# Altium schematic sheet SCM20260D-Power.SchDoc(SCM20260D-Power)
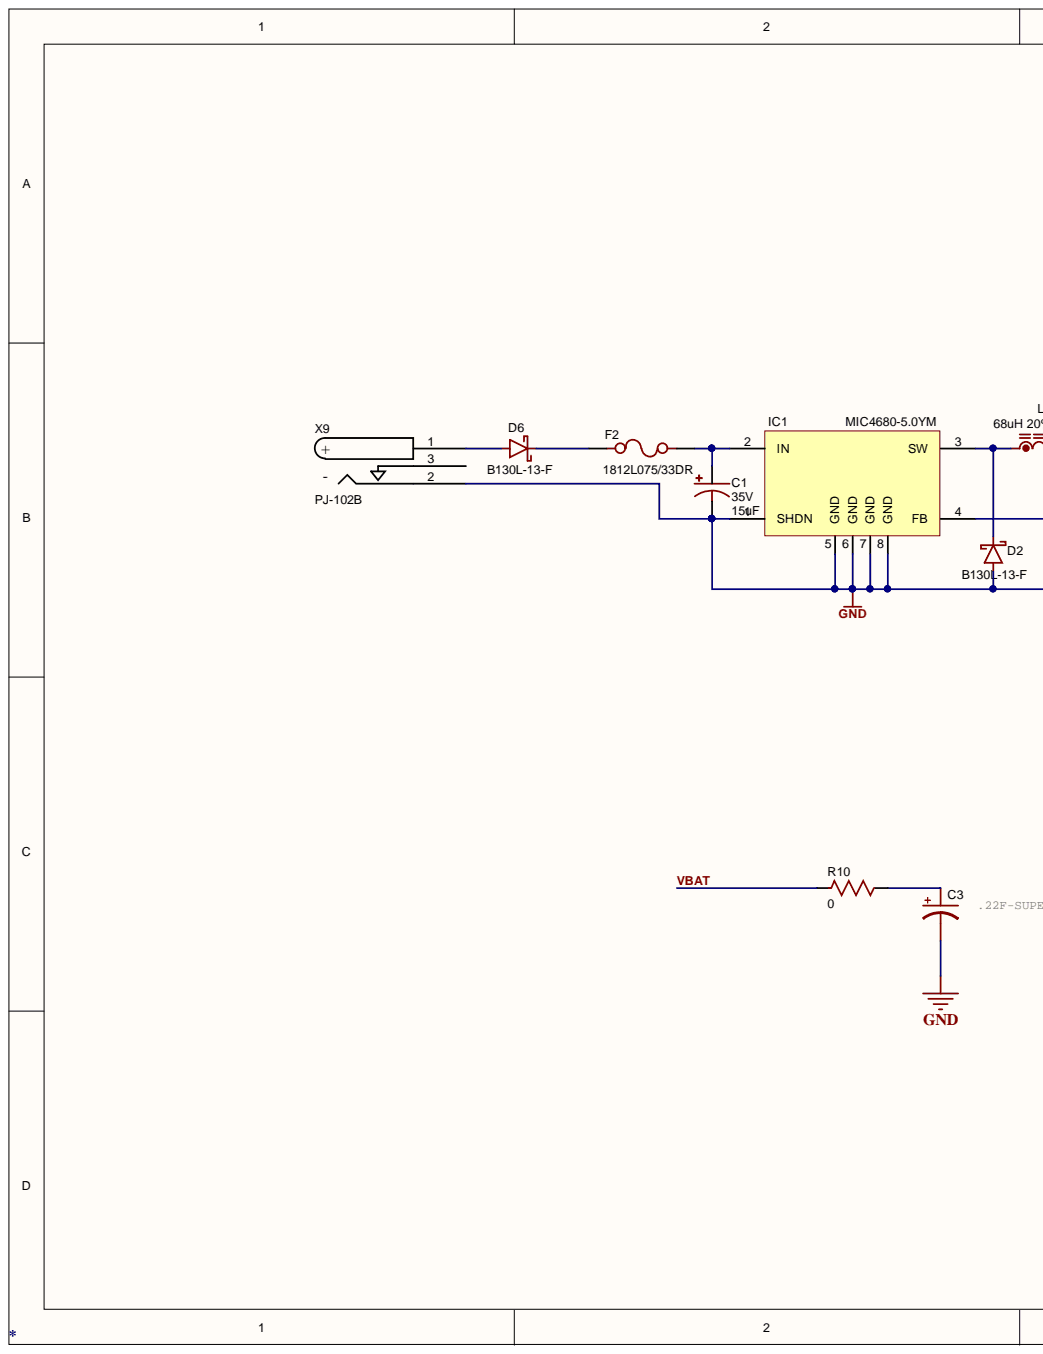
[diagram p0: SCM20260D-Power.SchDoc, left]
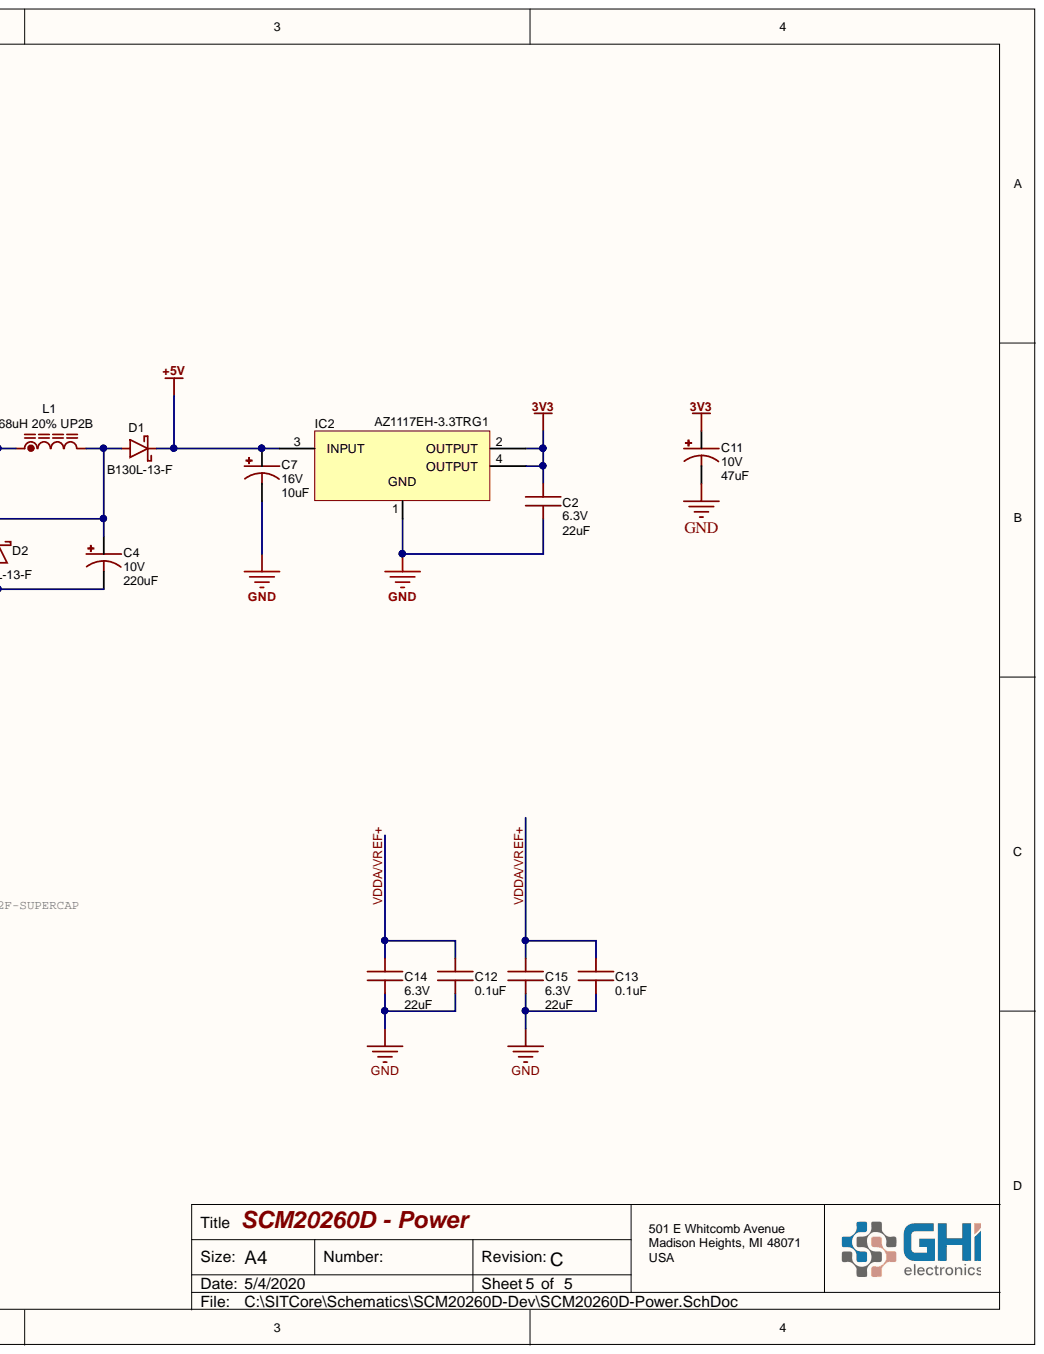
[diagram p1: SCM20260D-Power.SchDoc, right]

## Parts
C1  value 15uF  Voltage: 35V  [CASE-D (7343 METRIC)]  (hidden comment: CASE-D 7343 Tant 15uF)
C2  value 22uF  Voltage: 6.3V  [C0805]  (hidden comment: 0805 Cap 22uF)
C3  .22F-SUPERCAP  [DSK-RADIAL-6.8MM]
C4  value 220uF  Voltage: 10V  [CASE-D (7343 METRIC)]  (hidden comment: CASE-D 7343 Tant 220uF)
C7  value 10uF  Voltage: 16V  [CASE-B (3528 METRIC)]  (hidden comment: CASE-B  3528 Tant 10uF)
C11  value 47uF  Voltage: 10V  [CASE-B (3528 METRIC)]  (hidden comment: CASE-B  Tant 47uF)
C12  value 0.1uF  [C0603 (1608 Metric)]  (hidden comment: 0603 Cap 0.1uF)
C13  value 0.1uF  [C0603 (1608 Metric)]  (hidden comment: 0603 Cap 0.1uF)
C14  value 22uF  Voltage: 6.3V  [C0805]  (hidden comment: 0805 Cap 22uF)
C15  value 22uF  Voltage: 6.3V  [C0805]  (hidden comment: 0805 Cap 22uF)
D1  B130L-13-F  [SMA]
D2  B130L-13-F  [SMA]
D6  B130L-13-F  [SMA]
F2  value 1812L075/33DR  [1812 Fuse]
IC1  MIC4680-5.0YM  [8-Pin SOIC]
IC2  AZ1117EH-3.3TRG1  [SOT223]
L1  68uH 20% UP2B  Tolerance: 20%  Package: UP2B  Inductance: 68uH  [UP2BXXX]
R10  value 0  [R0603 (1608 Metric)]  (hidden comment: 0603 Res 0)
X9  PJ-102B  [PJ-102B Power Connector]

## Nets
3V3: C2.2 C11.+ IC2.2(OUTPUT) IC2.4(OUTPUT)
+5V: C7.+ D1.K IC2.3(INPUT)
GND: C1.- C2.1 C3.- C4.- C7.- C11.- C12.1 C13.1 C14.1 C15.1 D2.A IC1.1(SHDN) IC1.5(GND) IC1.6(GND) IC1.7(GND) IC1.8(GND) IC2.1 X9.2
VBAT: R10.1
VDDA/VREF+: C12.2 C13.2 C14.2 C15.2
NetC1_+: C1.+ F2.2 IC1.2(IN)
NetC3_+: C3.+ R10.2
NetC4_+: C4.+ D1.A IC1.4(FB) L1.2
NetD2_K: D2.K IC1.3(SW) L1.1
NetD6_A: D6.A X9.1
NetD6_K: D6.K F2.1

Not connected: X9 3

## Library data of the parts
C1 = CASE-D 7343 Tant 15uF: 15uF ±10% 35V 700 mΩ @ 100kHz -55℃ ~ +125℃ CASE-D_7343 Tantalum Capacitors RoHS; Mfr.Part #: 293D156X9035D2TE3; Tolerance: ±10%; Package: CASE-D_7343; LCSC Part #: C162325; Component Kind: Standard; Category: Tantalum; Capacitance: 15uF; Library Name: GHI-Passives.SchLib
C2 C14 C15 = 0805 Cap 22uF: 22uF ±20% 6.3V X5R 0805 Multilayer Ceramic Capacitors MLCC - SMD/SMT RoHS; Mfr.Part #: CL21A226MQCLRNC; Tolerance: ±20%; Package: 0805; LCSC Part #: C307530; Component Kind: Standard; Category: Ceramic; Capacitance: 22uF; Library Name: GHI-Passives.SchLib
C3 = .22F-SUPERCAP: ELNA DSK-621 - 220mF Electric Double Layer Capacitor (EDLC/SuperCapacitor)    A 220 mF SuperCapacitor. Used for backup power on devices with low standby current; Value: DSK-3R3H224U-HL; SymbolName_1: CAP_POL; GateName_1: G$1; PartNo: DSK-3R3H224U-HL; LibraryName: SparkFun-Capacitors; DeviceSetName: .22F-SUPERCAP; Component Kind: Standard; Library Name: GHI.SchLib
C4 = CASE-D 7343 Tant 220uF: 220uF ±10% 10V 500 mΩ @ 100kHz -55℃ ~ +125℃ CASE-D_7343 Tantalum Capacitors RoHS; Mfr.Part #: T491D227K010AT; Manufacturer: KEMET; Tolerance: ±10%; Package: CASE-D_7343; LCSC Part #: C116577; Component Kind: Standard; Category: Tantalum; Capacitance: 220uF; Library Name: GHI-Passives.SchLib
C7 = CASE-B Tant 10uF: 10uF ±10% 16V 800 mΩ @ 100kHz -55℃ ~ +125℃ CASE-B_3528 Tantalum Capacitors RoHS; Mfr.Part #: TPSB106K016R0800; Tolerance: ±10%; Package: CASE-B_3528; LCSC Part #: C273667; Digikey: 478-2398-1-ND; Component Kind: Standard; Category: Tantalum; Capacitance: 10uF; Library Name: GHI-Passives.SchLib
C11 = CASE-B  Tant 47uF: 47uF ±10% 10V 1 Ω @ 100kHz -55℃ ~ +125℃ CASE-B_3528 Tantalum Capacitors RoHS; Mfr.Part #: TAJB476K010RNJ; Tolerance: ±10%; Package: CASE-B 3528; LCSC Part #: C22036; Component Kind: Standard; Category: Tantalum; Capacitance: 47uF; Library Name: GHI-Passives.SchLib
C12 C13 = 0603 Cap 0.1uF: 0.1uF ±5% 50V X7R 0603 Multilayer Ceramic Capacitors MLCC - SMD/SMT RoHS; Mfr.Part #: CL10B104JB8NNNC; Voltage: 50V; Tolerance: ±5%; Package: 0603; LCSC Part #: C24452; Digikey: 1276-1033-1-ND; Component Kind: Standard; Category: Ceramic; Capacitance: 0.1uF; Library Name: GHI-Passives.SchLib
D1 D2 D6 = B130L-13-F 1A Schottky SMA: 30V 1A 410mV @ 1A SMA(DO-214AC) Schottky Barrier Diodes (SBD) RoHS; Manufacturer: Diodes Incorporated; GHI Part Number: EPC-DB130L-13; Value: B130L-13-F; Package: SMA(DO-214AC); Mfr.Part #: B130L-13-F; Library Name: GHI.SchLib; LCSC Part #: C134425; Component Type: Diode; Component Kind: Standard
F2 = FUSE 33V 750MA 1812: Polymeric PTC Resettable Fuse 33V 750mA Ih Surface Mount 1812 (4532 Metric), Concave; Manufacturer: Littelfuse Inc.; Voltage - Max: PTC RESET FUSE 33V 750MA 1812; Value: 1812L075/33DR; Current - Trip (It): 1.5A; Package: 1812; Mfr.Part #: 1812L075/33DR; LCSC Part #: C151170; Component Kind: Standard; : FUSE 33V 750MA 1812; Library Name: GHI-Passives.SchLib
IC1 = MIC4680-5.0YM: Micrel 1A 200kHz SuperSwitcher Buck Regulator; Value: MIC4680-5.0YM; PartNo: MIC4680-5.0YM; Component Kind: Standard; Library Name: GHI.SchLib
IC2 = AZ1117EH-3.3TRG1: Fixed 13V 3.3V 1A SOT-223 Low Dropout Regulators(LDO) RoHS; Manufacturer: Diodes Incorporated; Value: AZ1117EH-3.3TRG1; Package: SOT-223; Mfr.Part #: AZ1117EH-3.3TRG1; LCSC Part #: C108494; Component Kind: Standard; Library Name: GHI-Power.SchLib
L1 = 68uH 20%: Inductor 6.8uH 20% UP2B; GHI Part Number: EPC-LUP25-680; Value: UP2B-680-R; PartNo: UP2B-680-R; Library Name: GHI Basic Components.SchLib; Component Type: Inductor; Component Kind: Standard
R10 = 0603 Res 0: ±5% 1/10W 0603 Chip Resistor - Surface Mount RoHS; Mfr.Part #: RC0603JR-070RL; Manufacturer: YAGEO; Voltage Rating: *V; Resistance Tolerance: ±5%; Resistance: 0; Power Rating: 1/10W; Package: 0603; LCSC Part #: C95177; Component Kind: Standard; Library Name: GHI-Passives.SchLib
X9 = PJ-102B: Value: PJ-102B; Component Kind: Standard; Library Name: GHI Connectors.SchLib
